# Altium schematic sheet Sheet8.SchDoc
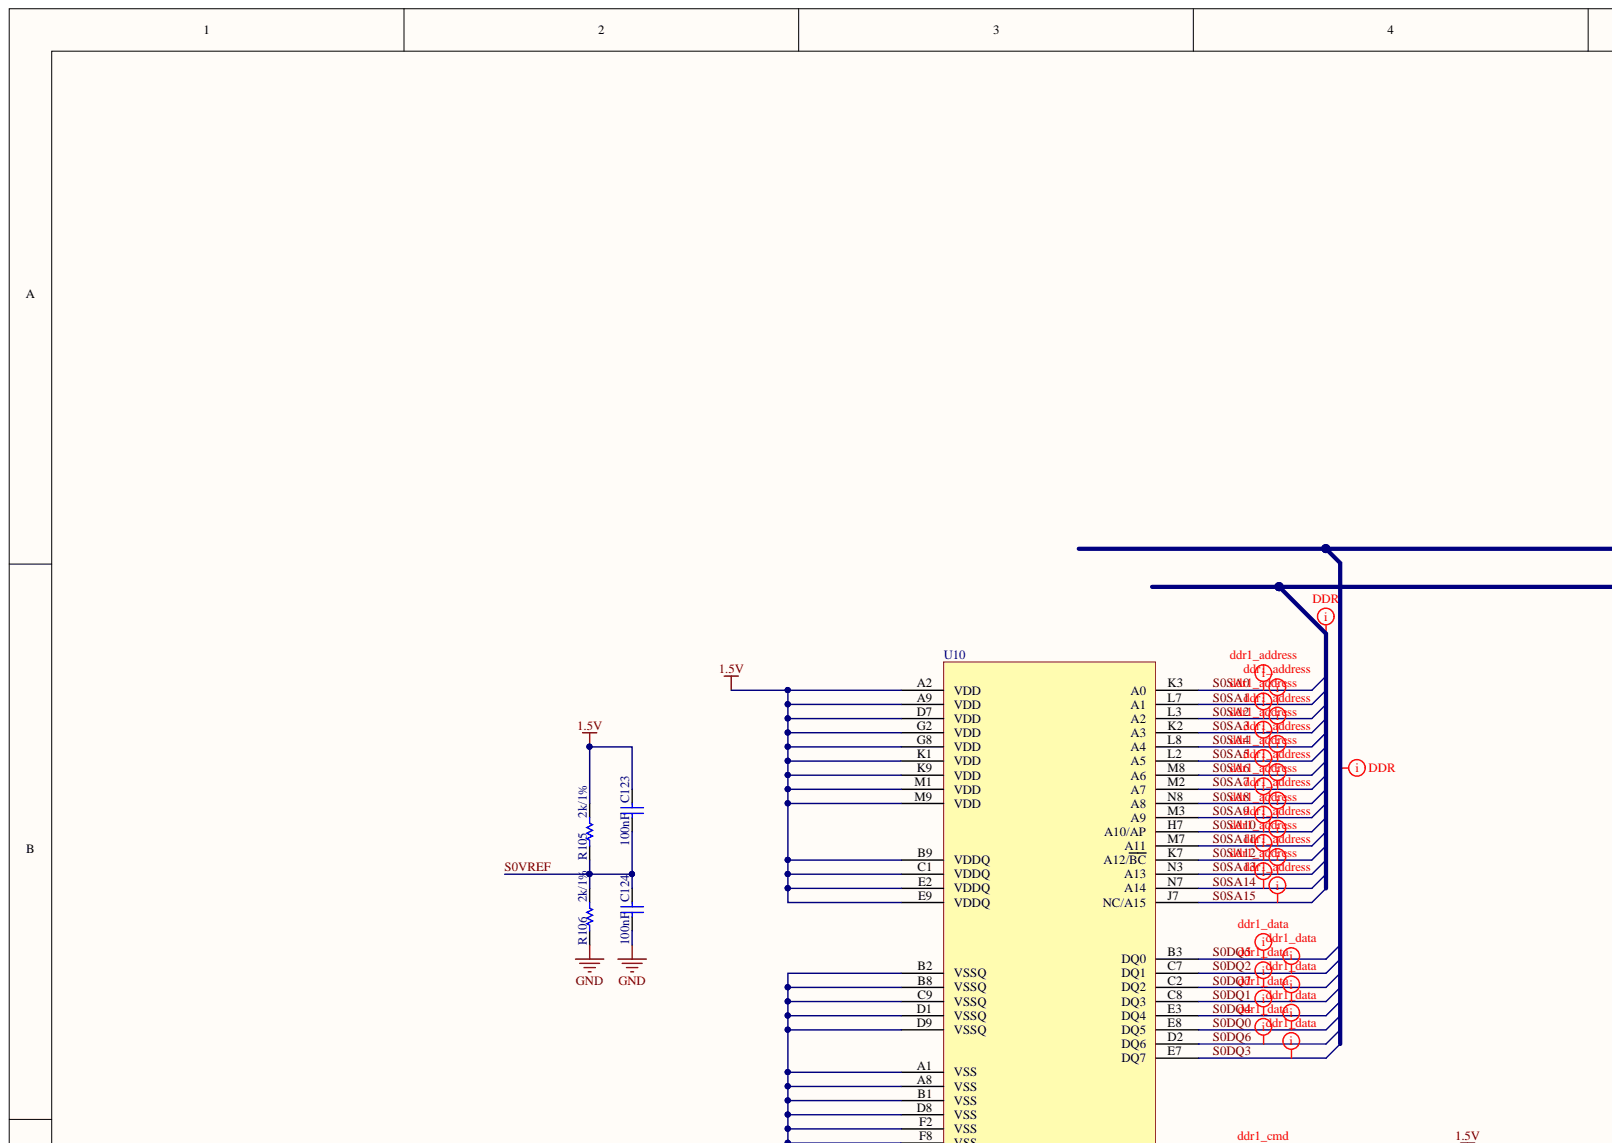
[diagram p0: Sheet8.SchDoc, top left]
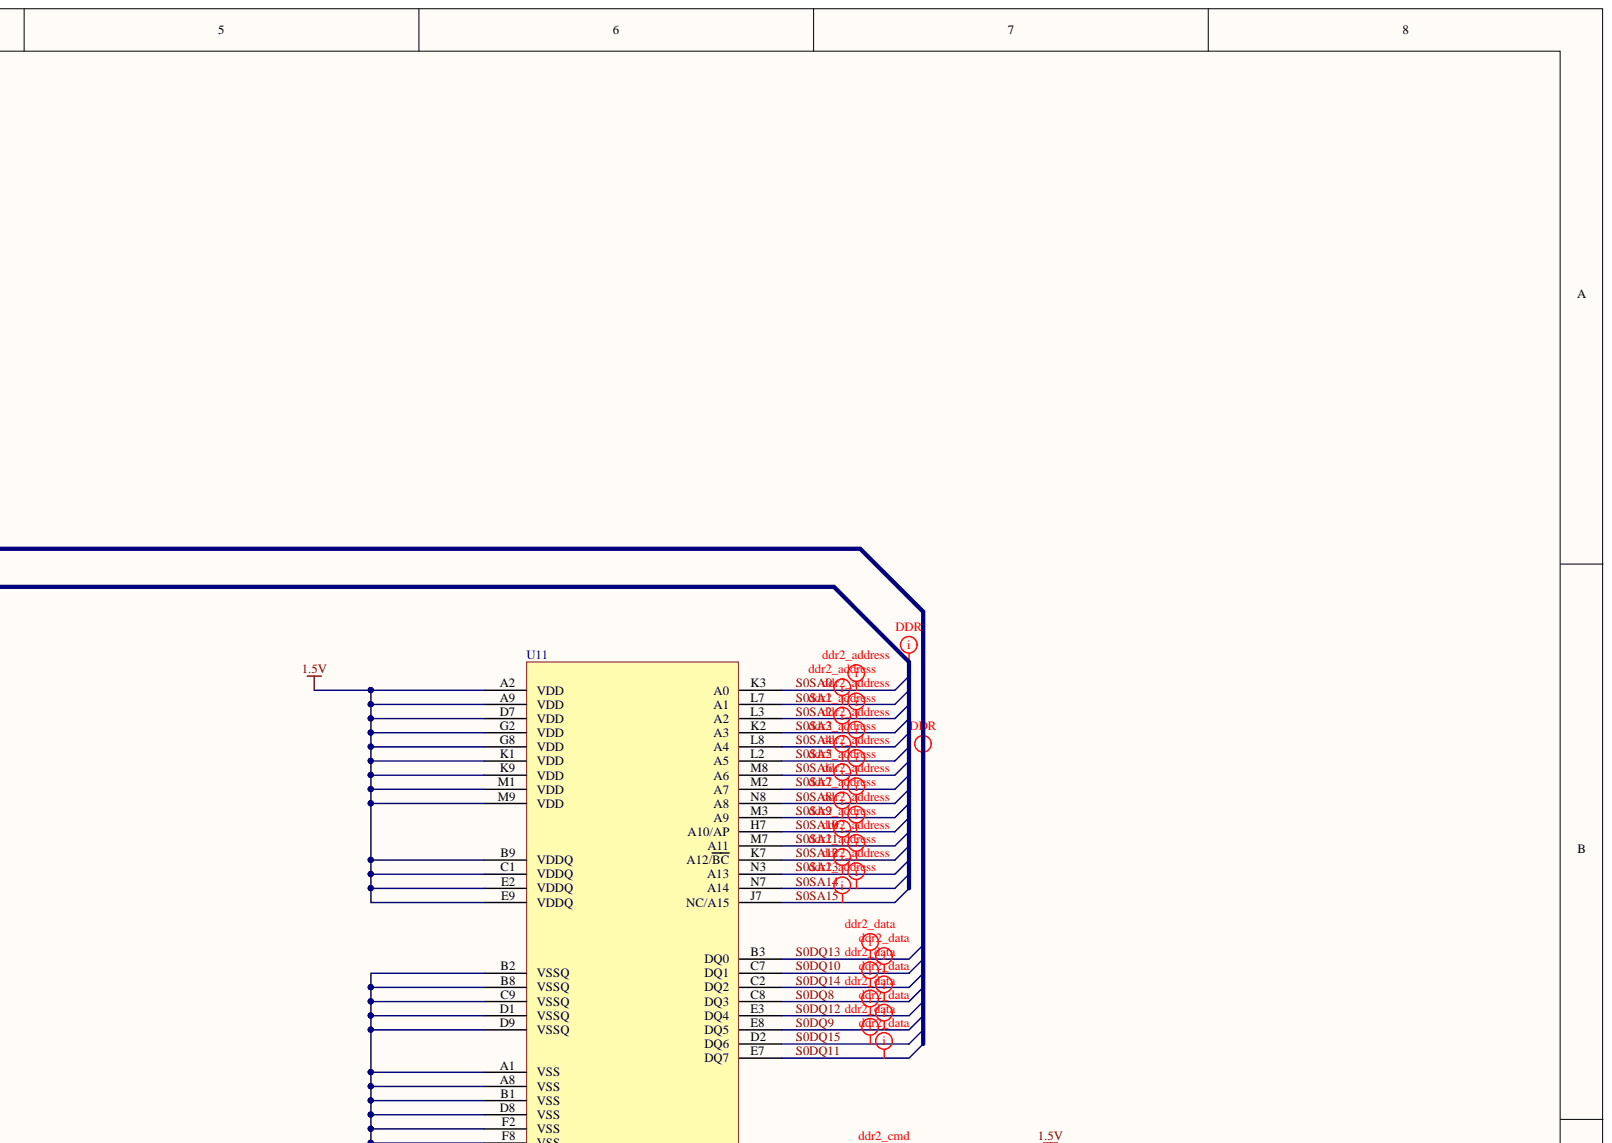
[diagram p1: Sheet8.SchDoc, top right]
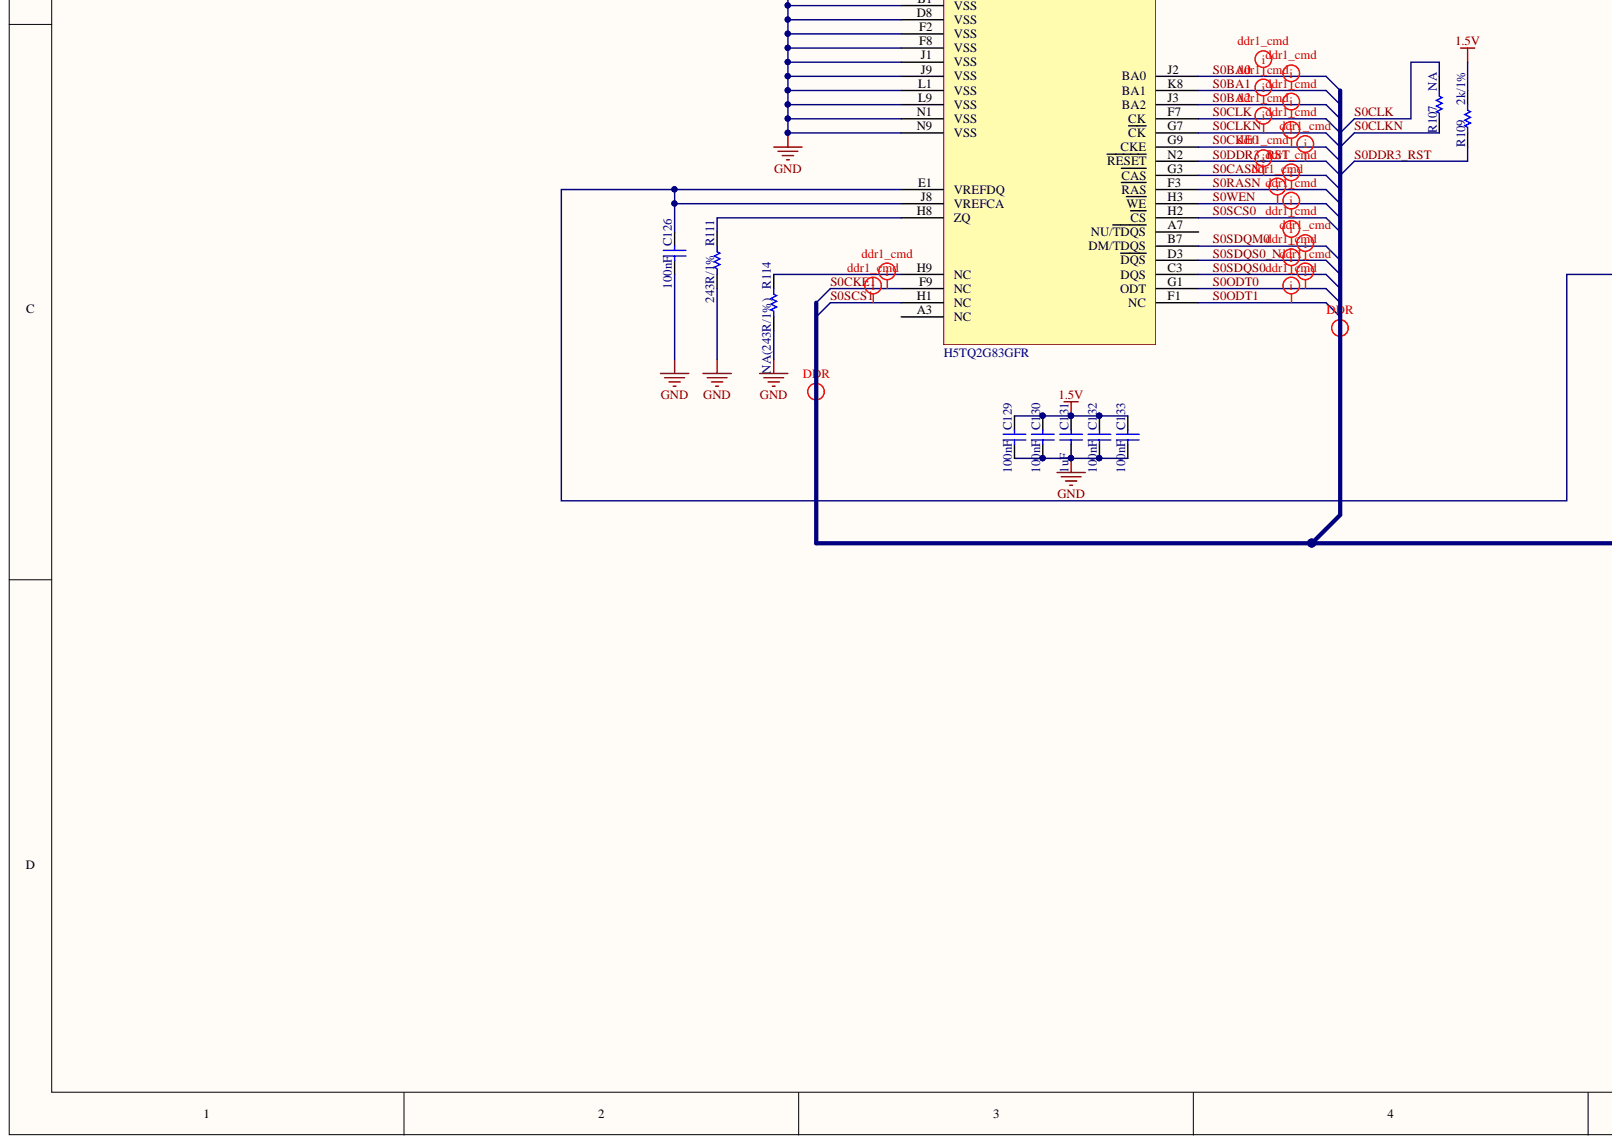
[diagram p2: Sheet8.SchDoc, bottom left]
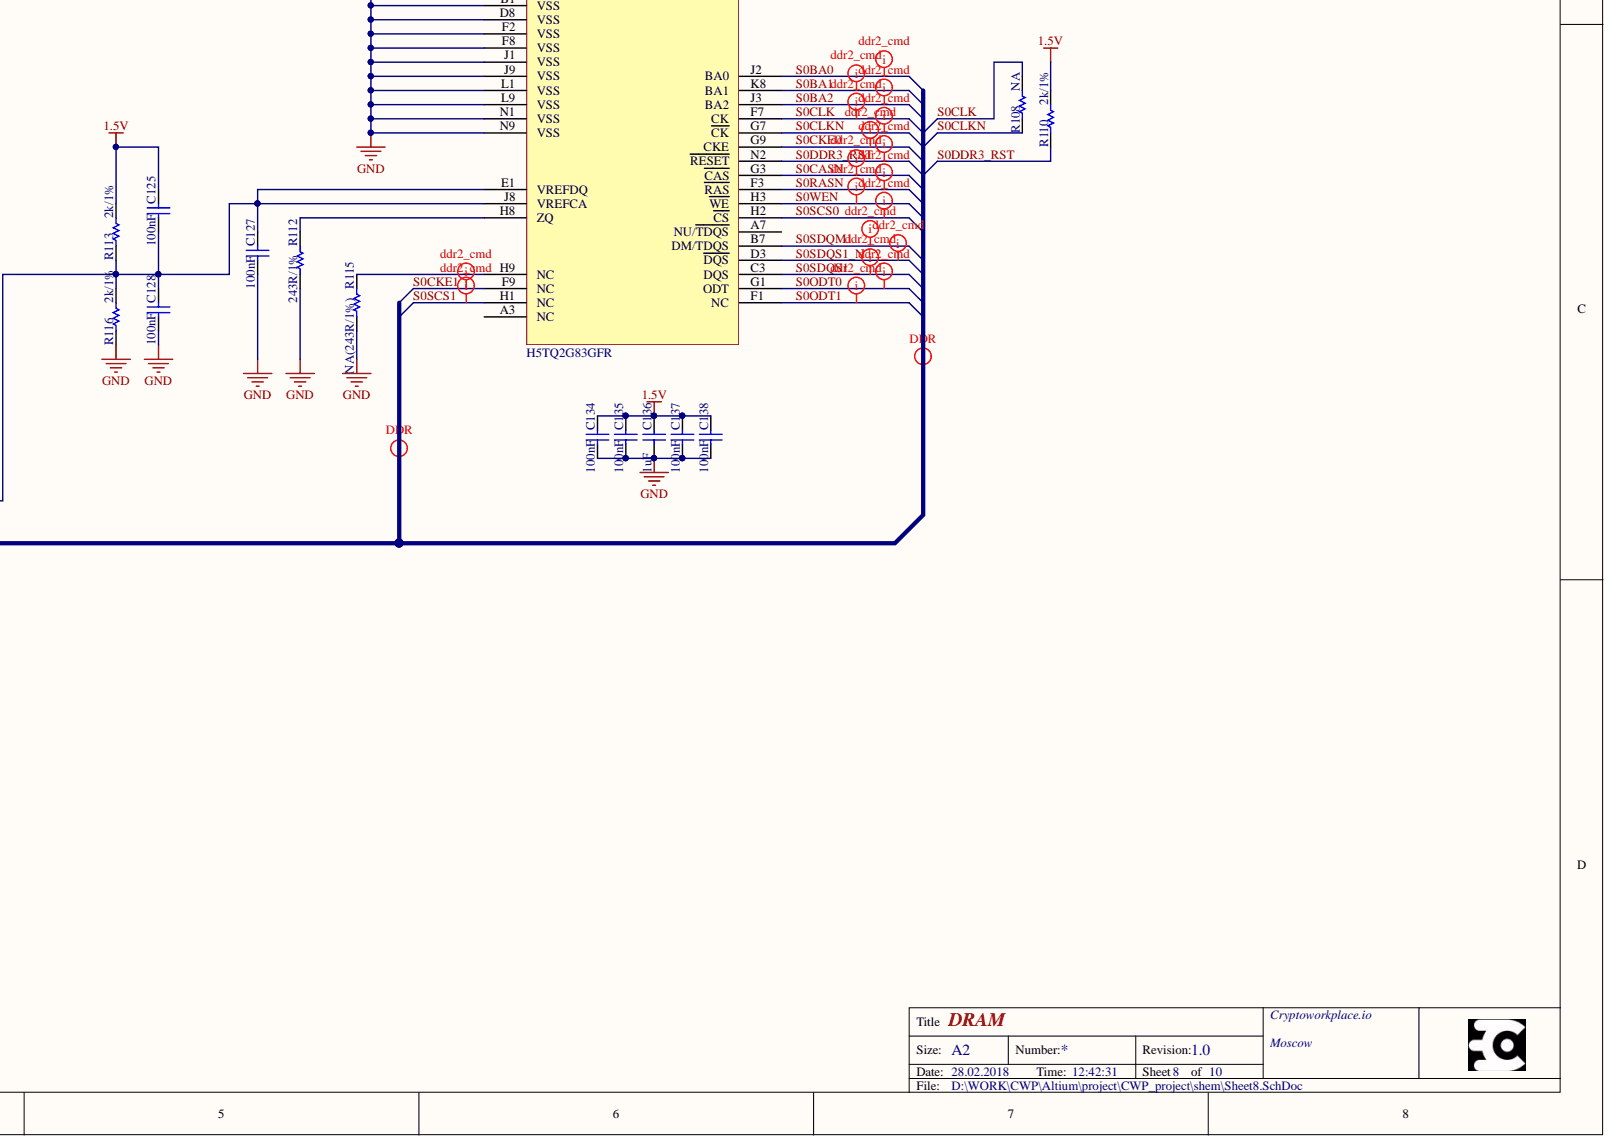
[diagram p3: Sheet8.SchDoc, bottom right]

## Parts
C123  value 100nF  [0402(CAPC1005X06N)]  (hidden comment: 0402)
C124  value 100nF  [0402(CAPC1005X06N)]  (hidden comment: 0402)
C125  value 100nF  [0402(CAPC1005X06N)]  (hidden comment: 0402)
C126  value 100nF  [0402(CAPC1005X06N)]  (hidden comment: 0402)
C127  value 100nF  [0402(CAPC1005X06N)]  (hidden comment: 0402)
C128  value 100nF  [0402(CAPC1005X06N)]  (hidden comment: 0402)
C129  value 100nF  [0402(CAPC1005X06N)]  (hidden comment: 0402)
C130  value 100nF  [0402(CAPC1005X06N)]  (hidden comment: 0402)
C131  value 1uF  [0402(CAPC1005X06N)]  (hidden comment: 0402)
C132  value 100nF  [0402(CAPC1005X06N)]  (hidden comment: 0402)
C133  value 100nF  [0402(CAPC1005X06N)]  (hidden comment: 0402)
C134  value 100nF  [0402(CAPC1005X06N)]  (hidden comment: 0402)
C135  value 100nF  [0402(CAPC1005X06N)]  (hidden comment: 0402)
C136  value 1uF  [0402(CAPC1005X06N)]  (hidden comment: 0402)
C137  value 100nF  [0402(CAPC1005X06N)]  (hidden comment: 0402)
C138  value 100nF  [0402(CAPC1005X06N)]  (hidden comment: 0402)
R105  value 2k/1%  [0402(RESC1005X04N)]  (hidden comment: Res1)
R106  value 2k/1%  [0402(RESC1005X04N)]  (hidden comment: Res1)
R107  value NA  [0402(RESC1005X04N)]  (hidden comment: Res1)
R108  value NA  [0402(RESC1005X04N)]  (hidden comment: Res1)
R109  value 2k/1%  [0402(RESC1005X04N)]  (hidden comment: Res1)
R110  value 2k/1%  [0402(RESC1005X04N)]  (hidden comment: Res1)
R111  value 243R/1%  [0402(RESC1005X04N)]  (hidden comment: Res1)
R112  value 243R/1%  [0402(RESC1005X04N)]  (hidden comment: Res1)
R113  value 2k/1%  [0402(RESC1005X04N)]  (hidden comment: Res1)
R114  value NA(243R/1%)  [0402(RESC1005X04N)]  (hidden comment: Res1)
R115  value NA(243R/1%)  [0402(RESC1005X04N)]  (hidden comment: Res1)
R116  value 2k/1%  [0402(RESC1005X04N)]  (hidden comment: Res1)
U10  H5TQ2G83GFR  [BGA78C80P9X13_900X1110X120]
U11  H5TQ2G83GFR  [BGA78C80P9X13_900X1110X120]

## Nets
1.5V: C123.2 C125.2 C129.2 C130.2 C131.2 C132.2 C133.2 C134.2 C135.2 C136.2 C137.2 C138.2 R105.2 R109.2 R110.2 R113.2 U10.A2(VDD) U10.A9(VDD) U10.B9(VDDQ) U10.C1(VDDQ) U10.D7(VDD) U10.E2(VDDQ) U10.E9(VDDQ) U10.G2(VDD) U10.G8(VDD) U10.K1(VDD) U10.K9(VDD) U10.M1(VDD) U10.M9(VDD) U11.A2(VDD) U11.A9(VDD) U11.B9(VDDQ) U11.C1(VDDQ) U11.D7(VDD) U11.E2(VDDQ) U11.E9(VDDQ) U11.G2(VDD) U11.G8(VDD) U11.K1(VDD) U11.K9(VDD) U11.M1(VDD) U11.M9(VDD)
GND: C124.1 C126.1 C127.1 C128.1 C129.1 C130.1 C131.1 C132.1 C133.1 C134.1 C135.1 C136.1 C137.1 C138.1 R106.1 R111.1 R112.1 R114.1 R115.1 R116.1 U10.A1(VSS) U10.A8(VSS) U10.B1(VSS) U10.B2(VSSQ) U10.B8(VSSQ) U10.C9(VSSQ) U10.D1(VSSQ) U10.D8(VSS) U10.D9(VSSQ) U10.F2(VSS) U10.F8(VSS) U10.J1(VSS) U10.J9(VSS) U10.L1(VSS) U10.L9(VSS) U10.N1(VSS) U10.N9(VSS) U11.A1(VSS) U11.A8(VSS) U11.B1(VSS) U11.B2(VSSQ) U11.B8(VSSQ) U11.C9(VSSQ) U11.D1(VSSQ) U11.D8(VSS) U11.D9(VSSQ) U11.F2(VSS) U11.F8(VSS) U11.J1(VSS) U11.J9(VSS) U11.L1(VSS) U11.L9(VSS) U11.N1(VSS) U11.N9(VSS)
S0BA0: U10.J2(BA0) U11.J2(BA0)
S0BA1: U10.K8(BA1) U11.K8(BA1)
S0BA2: U10.J3(BA2) U11.J3(BA2)
S0CASN: U10.G3(CAS) U11.G3(CAS)
S0CKE0: U10.G9(CKE) U11.G9(CKE)
S0CKE1: U10.F9(NC) U11.F9(NC)
S0CLK: R107.2 R108.2 U10.F7(CK) U11.F7(CK)
S0CLKN: R107.1 R108.1 U10.G7(CK) U11.G7(CK)
S0DDR3_RST: R109.1 R110.1 U10.N2(RESET) U11.N2(RESET)
S0DQ0: U10.E8(DQ5)
S0DQ1: U10.C8(DQ3)
S0DQ2: U10.C7(DQ1)
S0DQ3: U10.E7(DQ7)
S0DQ4: U10.E3(DQ4)
S0DQ5: U10.B3(DQ0)
S0DQ6: U10.D2(DQ6)
S0DQ7: U10.C2(DQ2)
S0DQ8: U11.C8(DQ3)
S0DQ9: U11.E8(DQ5)
S0DQ10: U11.C7(DQ1)
S0DQ11: U11.E7(DQ7)
S0DQ12: U11.E3(DQ4)
S0DQ13: U11.B3(DQ0)
S0DQ14: U11.C2(DQ2)
S0DQ15: U11.D2(DQ6)
S0ODT0: U10.G1(ODT) U11.G1(ODT)
S0ODT1: U10.F1(NC) U11.F1(NC)
S0RASN: U10.F3(RAS) U11.F3(RAS)
S0SA0: U10.K3(A0) U11.K3(A0)
S0SA1: U10.L7(A1) U11.L7(A1)
S0SA2: U10.L3(A2) U11.L3(A2)
S0SA3: U10.K2(A3) U11.K2(A3)
S0SA4: U10.L8(A4) U11.L8(A4)
S0SA5: U10.L2(A5) U11.L2(A5)
S0SA6: U10.M8(A6) U11.M8(A6)
S0SA7: U10.M2(A7) U11.M2(A7)
S0SA8: U10.N8(A8) U11.N8(A8)
S0SA9: U10.M3(A9) U11.M3(A9)
S0SA10: U10.H7(A10/AP) U11.H7(A10/AP)
S0SA11: U10.M7(A11) U11.M7(A11)
S0SA12: U10.K7(A12/BC) U11.K7(A12/BC)
S0SA13: U10.N3(A13) U11.N3(A13)
S0SA14: U10.N7(A14) U11.N7(A14)
S0SA15: U10.J7(NC/A15) U11.J7(NC/A15)
S0SCS0: U10.H2(CS) U11.H2(CS)
S0SCS1: U10.H1(NC) U11.H1(NC)
S0SDQM0: U10.B7(DM/TDQS)
S0SDQM1: U11.B7(DM/TDQS)
S0SDQS0: U10.C3(DQS)
S0SDQS0_N: U10.D3(DQS)
S0SDQS1: U11.C3(DQS)
S0SDQS1_N: U11.D3(DQS)
S0VREF: C123.1 C124.2 R105.1 R106.2
S0WEN: U10.H3(WE) U11.H3(WE)
NetC125_1: C125.1 C126.2 C127.2 C128.2 R113.1 R116.2 U10.E1(VREFDQ) U10.J8(VREFCA) U11.E1(VREFDQ) U11.J8(VREFCA)
NetR111_2: R111.2 U10.H8(ZQ)
NetR112_2: R112.2 U11.H8(ZQ)
NetR114_2: R114.2 U10.H9(NC)
NetR115_2: R115.2 U11.H9(NC)
N00528:
N00529:
N00530:
N00531:

Not connected: U10 A3(NC) A7(NU/TDQS); U11 A3(NC) A7(NU/TDQS)

## Library data of the parts
C123-C138 = Cap: Capacitor; Component Kind: Standard; LatestRevisionDate: 17-Jul-2002; LatestRevisionNote: Re-released for DXP Platform.; Library Name: Miscellaneous Devices.IntLib; PackageDescription: Radial Cap, Thru-Hole; 2 Leads; 0.3 in Pin Spacing; PackageReference: RAD-0.3; Published: 8-Jun-2000; Signal Integrity: Cap; Simulation: CAP; Publisher: Altium Limited
R105-R116 = Res1: Resistor; Component Kind: Standard; LatestRevisionDate: 17-Jul-2002; LatestRevisionNote: Re-released for DXP Platform.; Library Name: Miscellaneous Devices.IntLib; PackageDescription: Axial Device, Thru-Hole; 2 Leads; 0.3 in Pin Spacing; PackageReference: AXIAL-0.3; Published: 8-Jun-2000; Signal Integrity: Res; Simulation: RESISTOR; Publisher: Altium Limited
U10 U11 = H5TQ2G83GFR: Component Kind: Standard; Library Name: Hynix.SchLib
